# Altium schematic sheet 03-Actuators.SchDoc(03-Actuators)
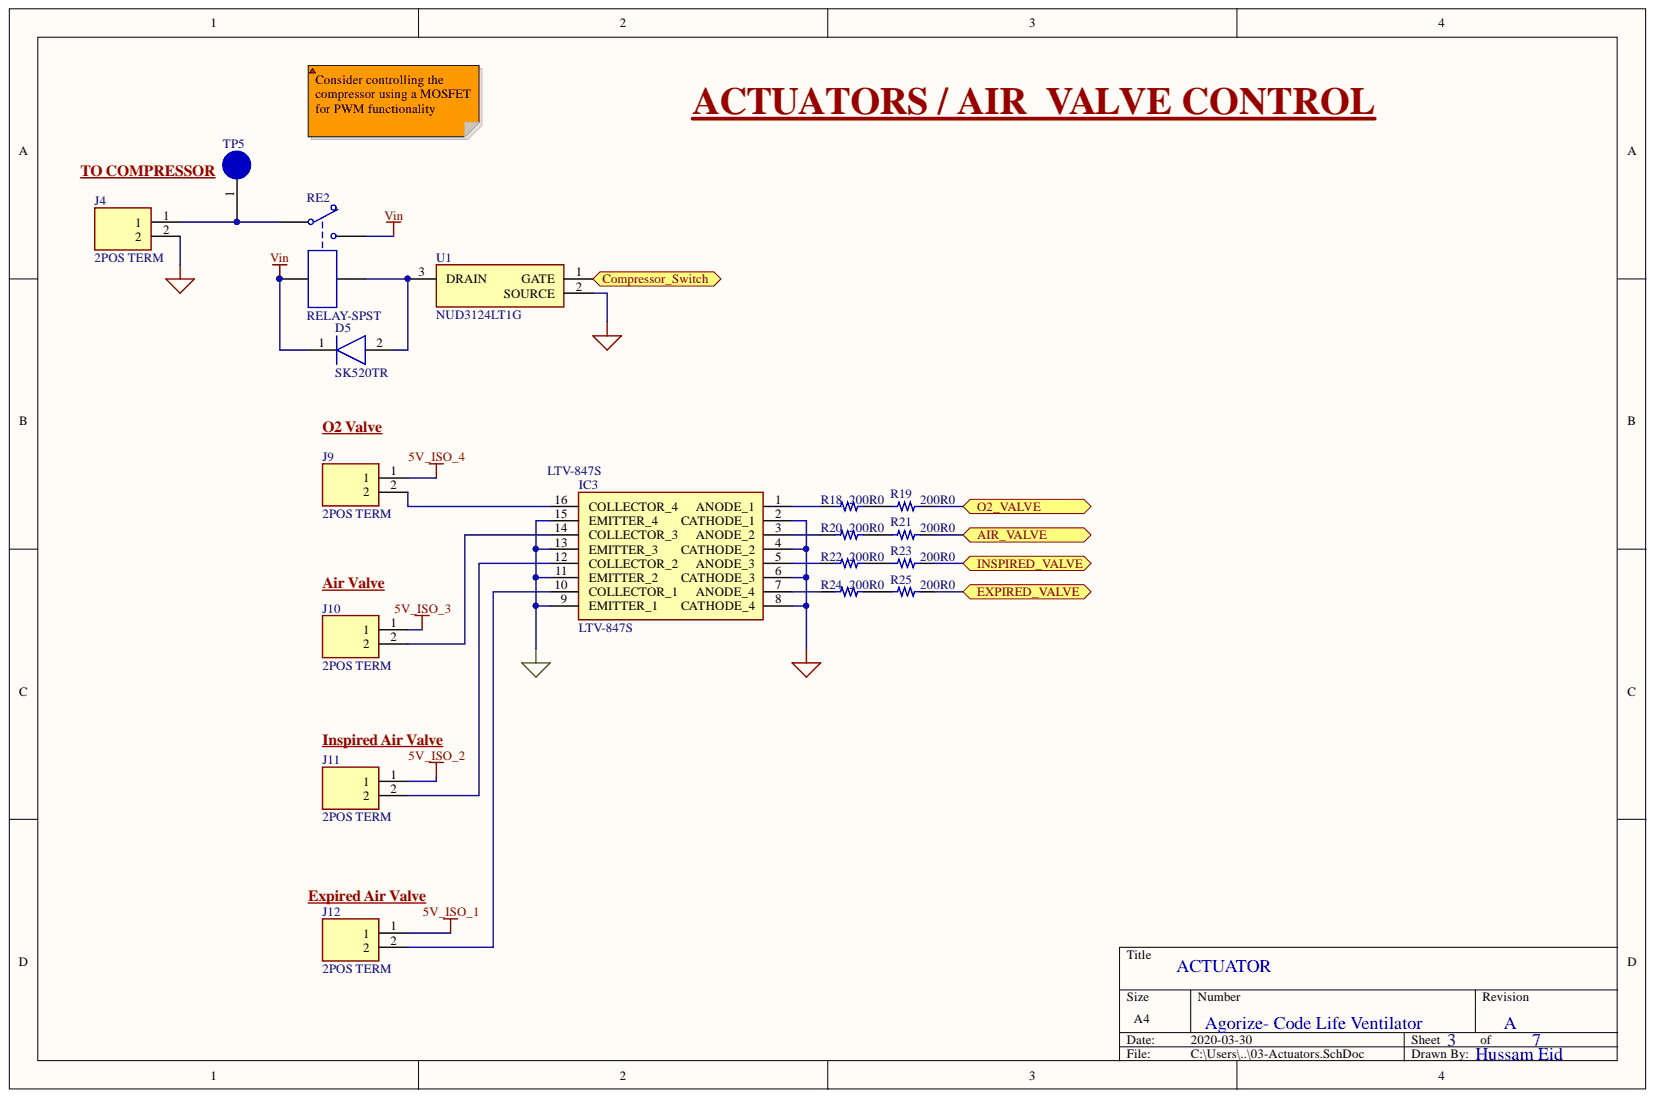
[diagram p0: 03-Actuators.SchDoc]

## Parts
D5  SK520TR  [DIOM8060X295N]
IC3  LTV-847S  [SOP254P1031X450-16N]
J4  2POS TERM  [SHDR2W110P0X500_1X2_1000X830X1140P]
J9  2POS TERM  [SHDR2W110P0X500_1X2_1000X830X1140P]
J10  2POS TERM  [SHDR2W110P0X500_1X2_1000X830X1140P]
J11  2POS TERM  [SHDR2W110P0X500_1X2_1000X830X1140P]
J12  2POS TERM  [SHDR2W110P0X500_1X2_1000X830X1140P]
R18  200R0  [RES 1206/3216]
R19  200R0  [RES 1206/3216]
R20  200R0  [RES 1206/3216]
R21  200R0  [RES 1206/3216]
R22  200R0  [RES 1206/3216]
R23  200R0  [RES 1206/3216]
R24  200R0  [RES 1206/3216]
R25  200R0  [RES 1206/3216]
RE2  RELAY-SPST  [JSM1A12V4]
TP5  TP  [TP]
U1  NUD3124LT1G  [SOT96P237X111-3N]

## Ports
AIR_VALVE, Compressor_Switch, EXPIRED_VALVE, INSPIRED_VALVE, O2_VALVE

## Nets
5V_ISO_1: J12.1
5V_ISO_2: J11.1
5V_ISO_3: J10.1
5V_ISO_4: J9.1
COM: IC3.2(CATHODE_1) IC3.4(CATHODE_2) IC3.6(CATHODE_3) IC3.8(CATHODE_4) J4.2 U1.2
COM_ISO: IC3.9(EMITTER_1) IC3.11(EMITTER_2) IC3.13(EMITTER_3) IC3.15(EMITTER_4)
Vin: D5.1 RE2.2 RE2.3
AIR_VALVE: R21.1 ; port AIR_VALVE
Compressor_Switch: U1.1 ; port Compressor_Switch
EXPIRED_VALVE: R25.1 ; port EXPIRED_VALVE
INSPIRED_VALVE: R23.1 ; port INSPIRED_VALVE
O2_VALVE: R19.1 ; port O2_VALVE
NetD5_2: D5.2 RE2.5 U1.3
NetIC3_1: IC3.1(ANODE_1) R18.2
NetIC3_3: IC3.3(ANODE_2) R20.2
NetIC3_5: IC3.5(ANODE_3) R22.2
NetIC3_7: IC3.7(ANODE_4) R24.2
NetIC3_10: IC3.10(COLLECTOR_1) J12.2
NetIC3_12: IC3.12(COLLECTOR_2) J11.2
NetIC3_14: IC3.14(COLLECTOR_3) J10.2
NetIC3_16: IC3.16(COLLECTOR_4) J9.2
NetJ4_1: J4.1 RE2.1 TP5.1
NetR18_1: R18.1 R19.2
NetR20_1: R20.1 R21.2
NetR22_1: R22.1 R23.2
NetR24_1: R24.1 R25.2

## Library data of the parts
D5 = SK520TR: DIODE SCHOTTKY 200V 5A SMC; Supplier Part Number 1: 1655-1603-1-ND; Supplier 1: Digi-Key; Stock 1: 19874; RoHS 1: No; Pricing 1: 1 = 0.35000, 10 = 0.28500, 25 = 0.26040, 100 = 0.16360, 250 = 0.16176, 500 = 0.15148, 1000 = 0.10300 (USD); Packaging: Tape and Reel; Mount: Surface Mount; Max Reverse Voltage (DC): 200V; Manufacturer Part Number 1: SK520TR; Manufacturer 1: SMC; Description 1: DIODE SCHOTTKY 200V 5A SMC; ComponentLink1URL: http://www.smc-diodes.com/propdf/SK520%20N0107%20REV.A.pdf?src-supplier=Digi-Key; ComponentLink1Description: http://www.smc-diodes.com/propdf/SK520%20N0107%20REV.A.pdf?src-supplier=Digi-Key; Component Kind: Standard; Case/Package: SMC; Average Rectified Current: 5A
IC3 = LTV-847S: OPTOISOLTR 5KV 4CH TRANS 16-SMD; Manufacturer 1: Vishay Lite-On; Rise Time: 18000ns; Reverse Breakdown Voltage: 6V; Power Dissipation: 200mW; Output Voltage: 35V; Output Current per Channel: 50mA; Number of Elements: 4; Forward Voltage: 1.4V; Current Transfer Ratio: 600%; Collector Emitter Saturation Voltage: 200mV; Supplier Part Number 1: 160-1371-5-ND; Supplier 1: Digi-Key; Stock 1: 44348; RoHS 1: Yes; Radiation Hardening: No; Pricing 1: 1 = 1.02000, 10 = 0.82500, 100 = 0.50760, 500 = 0.43146, 1000 = 0.35532, 2500 = 0.33756, 5000 = 0.32994, 10000 = 0.32233, 25000 = 0.31725 (USD); Packaging: Rail/Tube; Package Quantity: 25; Number of Pins: 16; Number of Circuits: 4; Number of Channels: 4; Mount: Surface Mount; Min Operating Temperature: -30°C; Max Power Dissipation: 200mW; Max Output Voltage: 35V; Max Operating Temperature: 110°C; Max Input Current: 50mA; Max Collector Current: 50mA; Manufacturer Part Number 1: LTV-847S; Lead Free: Lead Free; Isolation Voltage: 5kV; Forward Current: 50mA; Fall Time: 18000ns; Description 1: OPTOISOLTR 5KV 4CH TRANS 16-SMD; ComponentLink4URL: https://datasheet.ciiva.com/16294/ltv-817-827-847-16294014.pdf?src-supplier=Future+Electronics; ComponentLink4Description: https://datasheet.ciiva.com/16294/ltv-817-827-847-16294014.pdf?src-supplier=Future+Electronics; ComponentLink3URL: https://datasheet.ciiva.com/2086/s-110-ltv-817827847m-s-s-ta-s-ta1-s-tpseries-2086410.pdf?src-supplier=Digi-Key; ComponentLink3Description: https://datasheet.ciiva.com/2086/s-110-ltv-817827847m-s-s-ta-s-ta1-s-tpseries-2086410.pdf?src-supplier=Digi-Key; ComponentLink2URL: https://datasheet.ciiva.com/15118/getdatasheetpartid-119507-15118694.pdf?src-supplier=Verical; ComponentLink2Description: https://datasheet.ciiva.com/15118/getdatasheetpartid-119507-15118694.pdf?src-supplier=Verical; ComponentLink1URL: https://datasheet.ciiva.com/11771/0900766b80de6da2-11771782.pdf?src-supplier=RSComponents; ComponentLink1Description: https://datasheet.ciiva.com/11771/0900766b80de6da2-11771782.pdf?src-supplier=RSComponents; Component Kind: Standard; Collector Emitter Voltage (VCEO): 35V; Case/Package: SMD/SMT
J4 J9-J12 = 1935161: TERM BLK 2POS SIDE ENTRY 5MM PCB; Manufacturer 1: Phoenix Contact; Wire Gauge (Min): 12AWG; Wire Gauge (Max): 26AWG; Voltage Rating (DC): 320V; Voltage Rating: 250V; Voltage: 300V; Number of Positions Per Level: 2; Number of Levels: 1; Max Current Rating: 16A; Description 1: Conn Terminal Blocks 2 POS 5mm Solder ST Thru-Hole 16A; Current Rating: 17.5A; Current: 18A; Connector Type: Screw; Approvals: UL; Wire/Cable Gauge: 26AWG; Width: 14.9mm; Weight: 0.067021oz; Termination: Solder; Supplier Part Number 1: 277-1667-ND; Supplier 1: Digi-Key; Stock 1: 32563; RoHS 1: Yes; REACH SVHC: Unknown; Radiation Hardening: No; Pricing 1: 1 = 0.43000, 10 = 0.41100, 50 = 0.39280, 100 = 0.38200, 250 = 0.35700, 500 = 0.32844, 1000 = 0.30350 (USD); Pitch: 5mm; Packaging: Bulk; Package Quantity: 250; Orientation: Straight; Number of Rows: 1; Number of Positions: 2; Number of Poles: 2; Number of Pins: 2; Number of Contacts: 2; Number of Circuits: 2; Mount: Through Hole; Max Supply Voltage: 250V; Material: Nylon; Manufacturer Part Number 1: 1935161; Length: 9mm; Lead Free: Lead Free; Insulation Material: Nylon; Housing Material: Polyamide; Housing Color: Green; Height: 11.3mm; Flammability Rating: UL94 V-0; Depth: 9mm; Contact Material: Copper,Tin; ComponentLink3URL: https://datasheet.ciiva.com/824/combiconcompact5-0mmseries-824222.pdf?src-supplier=Digi-Key; ComponentLink3Description: https://datasheet.ciiva.com/824/combiconcompact5-0mmseries-824222.pdf?src-supplier=Digi-Key; ComponentLink2URL: https://datasheet.ciiva.com/16047/1935161-16047247.pdf?src-supplier=Onlinecomponents.com; ComponentLink2Description: https://datasheet.ciiva.com/16047/1935161-16047247.pdf?src-supplier=Onlinecomponents.com; ComponentLink1URL: https://datasheet.ciiva.com/15169/getdatasheetpartid-177903-15169663.pdf?src-supplier=Verical; ComponentLink1Description: https://datasheet.ciiva.com/15169/getdatasheetpartid-177903-15169663.pdf?src-supplier=Verical; Component Kind: Standard; Color: Green
R18-R25 = ERJ-8ENF1002V: RES SMD 10K OHM 1% 1/4W 1206; Manufacturer Part Number 1: RC1206FR-07200RL; Manufacturer 1: Yageo; Voltage Rating (DC): 200V; Temperature Coefficient: 100ppm/°C; Resistance: 200R; Power Rating: 250mW; Description 1: Res Thick Film 1206 200 Ohm 1% 0.25W(1/4W) ±100ppm/C Molded SMD Paper T/R; Database Table Name: Resistor - Chip; Width: 1.6mm; Weight: 0.000571oz; Voltage Rating: 200V; Tolerance: 1%; Termination: SMD/SMT; Supplier Part Number 1: 311-200FRCT-ND; Supplier 1: Digi-Key; Stock 1: 2870115; RoHS 1: Yes; Pricing 1: 1 = 0.10000, 10 = 0.07100, 100 = 0.02910, 1000 = 0.01306, 2500 = 0.01134 (USD); Packaging: Tape and Reel; Mount: Surface Mount; Min Operating Temperature: -55°C; Military Standard: Not; Max Operating Temperature: 155°C; Library Name: Celestial Library Database.DbLib; Length: 3.1mm; Lead Free: Lead Free; Height: 0.55mm; Depth: 1.6mm; Composition: Thick Film; ComponentLink3URL: https://datasheet.ciiva.com/11808/0900766b80c6630a-11808603.pdf?src-supplier=RSComponents; ComponentLink3Description: https://datasheet.ciiva.com/11808/0900766b80c6630a-11808603.pdf?src-supplier=RSComponents; ComponentLink2URL: http://digikey.com/product-detail/en/ERJ-8ENF1002V/P10.0KFTR-ND/89059; ComponentLink2Description: Digi-Key listing; ComponentLink1URL: http://industrial.panasonic.com/www-cgi/jvcr13pz.cgi?E+PZ+3+AOA0002+ERJ8ENF1002V+7+WW; ComponentLink1Description: Datasheet; Component Kind: Standard; Case Code (Metric): 3216; Case Code (Imperial): 1206; Case/Package: 1206
RE2 = RELAY-SPST: RELAY AUTOMOTIVE SPST 15A 12V; Manufacturer Part Number 1: JSM1A-12V-5; Manufacturer 1: Panasonic; Release Time: 10ms; Power Consumption: 640mW; Max Voltage Rating (DC): 16V; Coil Type: Non-Latching; Coil Resistance: 225R; Coil Current: 53.3mA; Weight: 12g; Throw Configuration: SPST; Termination: PC Pin; Supplier Part Number 1: 255-2815-ND; Supplier 1: Digi-Key; Stock 1: 2893; RoHS 1: Yes; Relay Type: Automotive; Pricing 1: 1 = 1.75000, 10 = 1.64300, 25 = 1.46000, 50 = 1.38700, 100 = 1.31400, 250 = 1.16800, 500 = 1.09500, 1000 = 1.02200, 5000 = 0.98550 (USD); Packaging: Bulk; Package Quantity: 100; Operate Time: 10ms; Number of Pins: 4; Mount: Through Hole; Min Operating Temperature: -40°C; Max Operating Temperature: 85°C; Max Current Rating: 15A; Library Name: Schlib1.SchLib; Lead Free: Lead Free; Description 1: RELAY AUTOMOTIVE SPST 15A 12V; Contact Material: Silver,Cadmium; Contact Current Rating: 15A; ComponentLink1URL: https://datasheet.ciiva.com/2408/ds-61211-en-jsm-pd-2408039.pdf?src-supplier=Digi-Key; ComponentLink1Description: https://datasheet.ciiva.com/2408/ds-61211-en-jsm-pd-2408039.pdf?src-supplier=Digi-Key; Component Kind: Standard; Coil Voltage (DC): 12V; Coil Power: 640mW
TP5 = TP: Test Point; Library Name: Schlib1.SchLib; Component Kind: Standard
U1 = NUD3124LT1G: IC PWR DRVR N-CHAN 1:1 SOT23-3; Manufacturer 1: ON Semiconductor; Rise Time: 708ns; Power Dissipation: 225mW; Output Voltage: 28V; Output Current: 200mA; Output Configuration: Low Side; On-State Resistance: 1.4R; Number of Outputs: 1; Max Output Current: 150mA; Interface: On/Off; Gate to Source Voltage (Vgs): 12V; Element Configuration: Single; Drain to Source Resistance: 800mR; Description 1: Trans MOSFET N-CH 28V 0.15A 3-Pin SOT-23 T/R; Width: 1.4mm; Supplier Part Number 1: NUD3124LT1GOSCT-ND; Supplier 1: Digi-Key; Stock 1: 48744; RoHS 1: Yes; REACH SVHC: No SVHC; Radiation Hardening: No; Pricing 1: 1 = 0.40000, 10 = 0.34200, 25 = 0.31880, 100 = 0.23690, 250 = 0.20044, 500 = 0.19132, 1000 = 0.14121 (USD); Packaging: Tape and Reel; Package Quantity: 3000; Number of Pins: 3; Number of Elements: 1; Number of Drivers: 1; Mount: Surface Mount; Min Operating Temperature: -40°C; Max Supply Voltage: 28V; Max Operating Temperature: 125°C; Manufacturer Part Number 1: NUD3124LT1G; Length: 3.04mm; Lead Free: Lead Free; Height: 1.01mm; Halogen Free: Halogen Free; Fall Time: 708ns; Drain to Source Voltage (Vdss): 28V; Drain to Source Breakdown Voltage: 28V; Current Rating: 200mA; Continuous Drain Current (ID): 150mA; Contact Plating: Tin,Matte; ComponentLink9URL: https://datasheet.ciiva.com/16200/nud3124-d-16200989.pdf?src-supplier=Future+Electronics; ComponentLink9Description: https://datasheet.ciiva.com/16200/nud3124-d-16200989.pdf?src-supplier=Future+Electronics; ComponentLink8URL: https://datasheet.ciiva.com/11166/nud3124-d-11166028.pdf?src-supplier=Avnet; ComponentLink8Description: https://datasheet.ciiva.com/11166/nud3124-d-11166028.pdf?src-supplier=Avnet; ComponentLink7URL: https://datasheet.ciiva.com/26145/nud3124-d-26145435.pdf?src-supplier=Avnet+Europe; ComponentLink7Description: https://datasheet.ciiva.com/26145/nud3124-d-26145435.pdf?src-supplier=Avnet+Europe; ComponentLink6URL: https://datasheet.ciiva.com/1609/1792914-1609179.pdf?src-supplier=Newark; ComponentLink6Description: https://datasheet.ciiva.com/1609/1792914-1609179.pdf?src-supplier=Newark; ComponentLink5URL: https://datasheet.ciiva.com/1955/nud3124-d-1955015.pdf?src-supplier=Digi-Key; ComponentLink5Description: https://datasheet.ciiva.com/1955/nud3124-d-1955015.pdf?src-supplier=Digi-Key; ComponentLink4URL: https://datasheet.ciiva.com/16486/nud3124-d-16486430.pdf?src-supplier=Avnet+Asia; ComponentLink4Description: https://datasheet.ciiva.com/16486/nud3124-d-16486430.pdf?src-supplier=Avnet+Asia; ComponentLink3URL: https://datasheet.ciiva.com/1955/nud3124-d-1955017.pdf?src-supplier=Digi-Key; ComponentLink3Description: https://datasheet.ciiva.com/1955/nud3124-d-1955017.pdf?src-supplier=Digi-Key; ComponentLink2URL: https://datasheet.ciiva.com/11168/nud3124-d-11168232.pdf?src-supplier=Avnet; ComponentLink2Description: https://datasheet.ciiva.com/11168/nud3124-d-11168232.pdf?src-supplier=Avnet; ComponentLink1URL: https://datasheet.ciiva.com/1955/nud3124-d-1955016.pdf?src-supplier=Digi-Key; ComponentLink1Description: https://datasheet.ciiva.com/1955/nud3124-d-1955016.pdf?src-supplier=Digi-Key; Component Kind: Standard; Case/Package: SOT-23
